# Altium schematic sheet boost_sheet.SchDoc
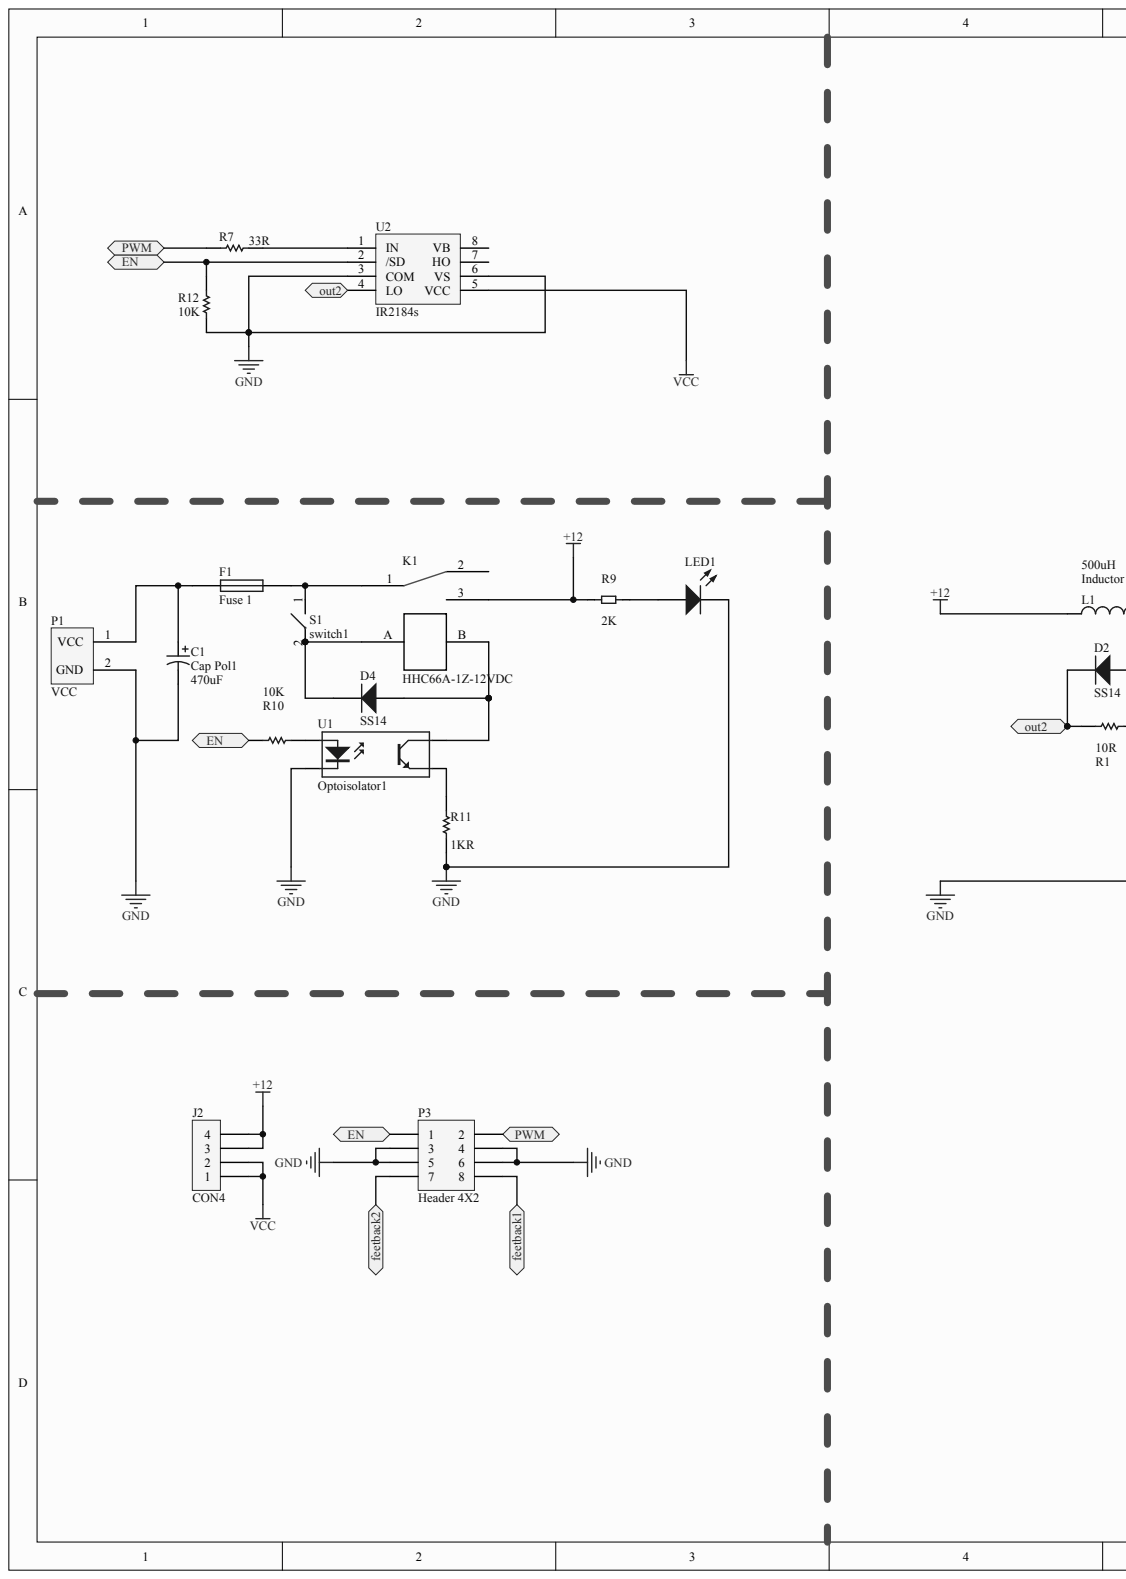
[diagram p0: boost_sheet.SchDoc, left]
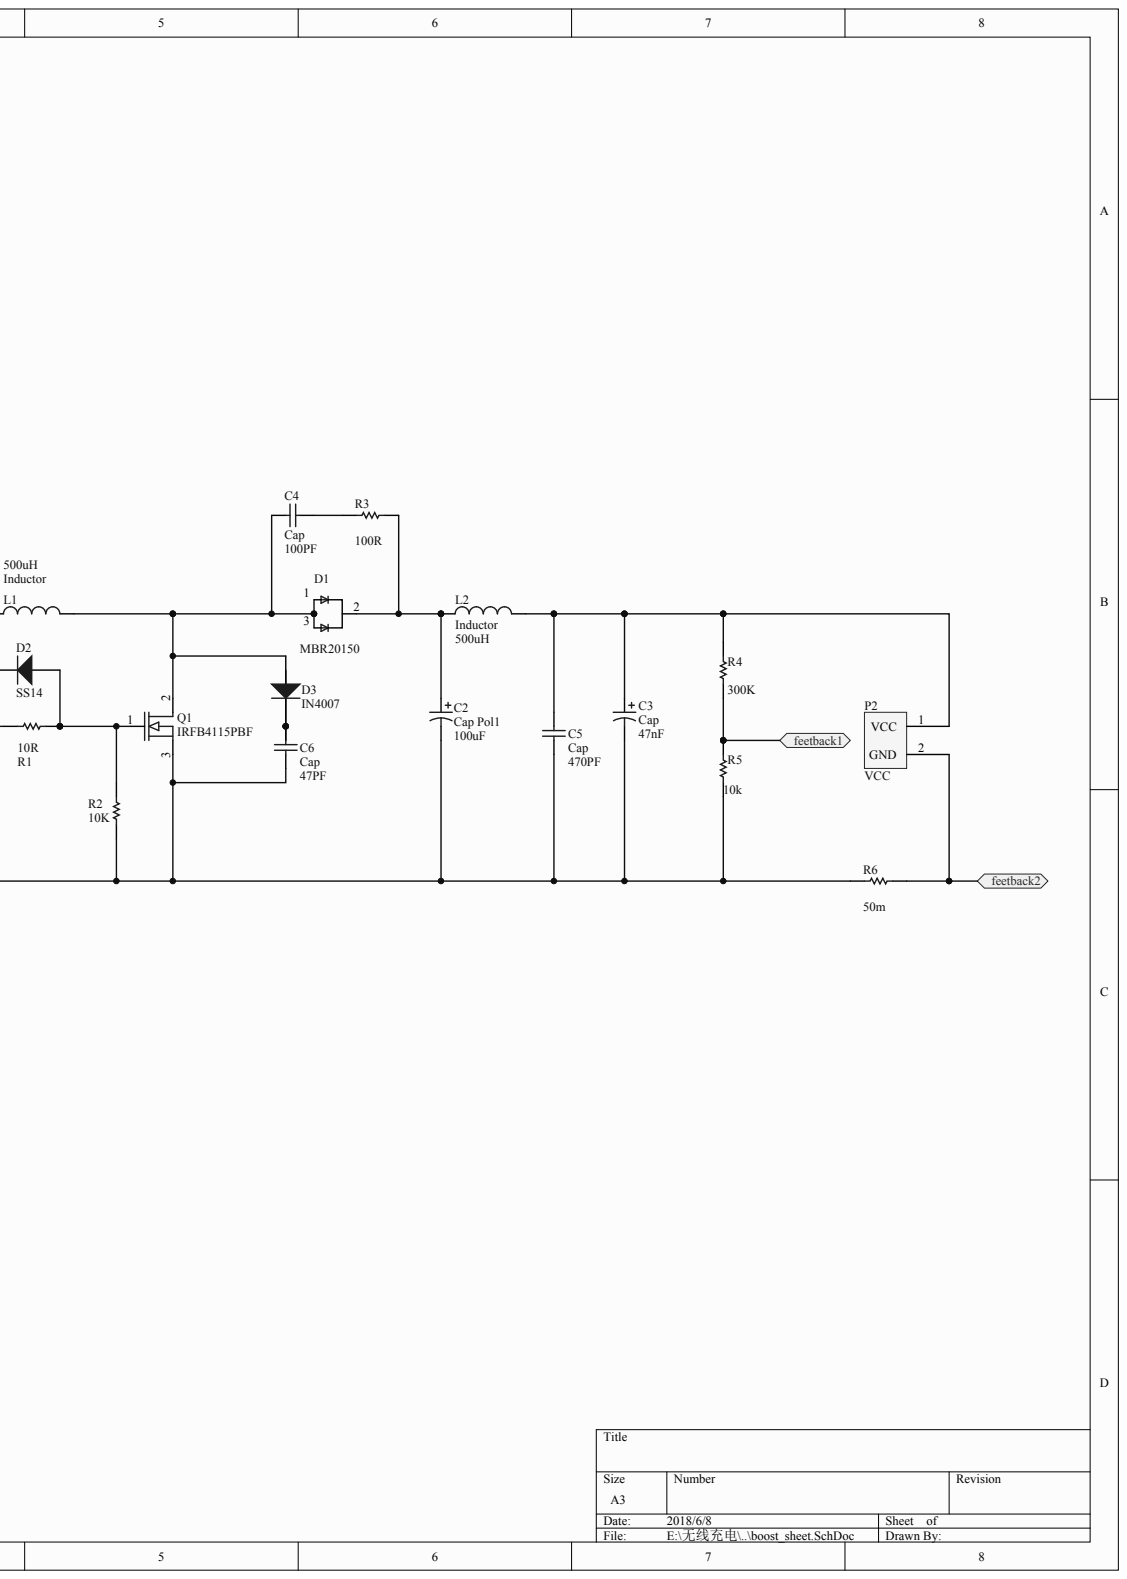
[diagram p1: boost_sheet.SchDoc, right]

## Parts
C1  Cap Pol1  value 470uF
C2  Cap Pol1  value 100uF
C3  Cap  value 47nF
C4  Cap  value 100PF
C5  Cap  value 470PF
C6  Cap  value 47PF
D1  MBR20150
D2  SS14
D3  IN4007
D4  SS14
F1  Fuse 1
J2  CON4
K1  HHC66A-1Z-12VDC
L1  Inductor  value 500uH
L2  Inductor  value 500uH
LED1  (no value)
P1  VCC
P2  VCC
P3  Header 4X2
Q1  IRFB4115PBF
R1  value 10R  (hidden comment: Res1)
R2  value 10K  (hidden comment: Res1)
R3  value 100R  (hidden comment: Res1)
R4  value 300K  (hidden comment: Res1)
R5  value 10k  (hidden comment: Res1)
R6  value 50m  (hidden comment: Res1)
R7  value 33R  (hidden comment: Res1)
R9  2K
R10  value 10K  (hidden comment: Res1)
R11  value 1KR  (hidden comment: Res1)
R12  value 10K  (hidden comment: Res1)
S1  switch1
U1  Optoisolator1
U2  IR2184s

## Ports
EN, FEETBACK1, FEETBACK2, OUT2, PWM

## Nets
+12: J2.3 J2.4 K1.3 L1.1 R9.2
GND: C1.2 C2.2 C3.2 C5.1 C6.1 LED1.2 P1.2 P3.3 P3.4 P3.5 P3.6 Q1.3 R2.1 R5.1 R6.2 R11.1 R12.1 U1.2 U2.3(COM) U2.6(VS)
VCC: J2.1 J2.2 U2.5(VCC)
EN: P3.1 R10.1 R12.2 U2.2(/SD) ; port EN
PWM: P3.2 R7.1 ; port PWM
NetC1_1: C1.1 F1.1 P1.1
NetC2_1: C2.1 D1.2 L2.1 R3.1
NetC3_1: C3.1 C5.2 L2.2 P2.1 R4.2
NetC4_1: C4.1 R3.2
NetC4_2: C4.2 D1.1 D1.3 D3.1 L1.2 Q1.2
NetC6_2: C6.2 D3.2
NetD2_1: D2.1 Q1.1 R1.2 R2.2
NetD2_2: D2.2 R1.1 U2.4(LO) ; port OUT2
NetD4_1: D4.1 K1.B U1.4
NetD4_2: D4.2 K1.A S1.2
NetF1_2: F1.2 K1.1 S1.1
NetLED1_1: LED1.1 R9.1
NetP2_2: P2.2 P3.7 R6.1 ; port FEETBACK2
NetP3_8: P3.8 R4.1 R5.2 ; port FEETBACK1
NetR7_2: R7.2 U2.1(IN)
NetR10_2: R10.2 U1.1
NetR11_2: R11.2 U1.3

Not connected: K1 2; U2 7(HO) 8(VB)

## Library data of the parts
L1 L2 = (no symbol name): Code_IEC: 1005; Published: 8-Jun-2000; LatestRevisionDate: 29-May-2009; LatestRevisionNote: IPC-7351 Footprint Added.; PackageReference: 0402-A; PackageDescription: Chip Inductor; Code_JEITA: 0402; Publisher: Altium Limited
C1-C6 D1-D4 F1 J2 K1 LED1 P1-P3 Q1 R1-R7 R9-R12 S1 U1 U2 = (no symbol name)
  C1-C3: Published: 8-Jun-2000; LatestRevisionDate: 17-Jul-2002; LatestRevisionNote: Re-released for DXP Platform.; PackageReference: RB7.6-15; PackageDescription: Polarized Capacitor (Radial); 2 Leads
  C4-C6: Published: 8-Jun-2000; LatestRevisionDate: 17-Jul-2002; LatestRevisionNote: Re-released for DXP Platform.; PackageReference: RAD-0.3; PackageDescription: Radial Cap, Thru-Hole; 2 Leads; 0.3 in Pin Spacing
  D2-D4: Published: 8-Jun-2000; LatestRevisionDate: 17-Jul-2002; LatestRevisionNote: Re-released for DXP Platform.; PackageVersion: Sep-1996; PackageReference: SMC; PackageDescription: DO-214-AB/SMC; 2 C-Bend Leads; Body 7.9 x 5.9 mm, inc. leads (LxW); Code_JEDEC: DO-214-AB
  F1: Published: 8-Jun-2000; LatestRevisionDate: 17-Jul-2002; LatestRevisionNote: Re-released for DXP Platform.; PackageReference: PIN-W2/E2.8; PackageDescription: Thru-Hole; 2 Leads
  LED1: Published: 8-Jun-2000; LatestRevisionDate: 17-Jul-2002; LatestRevisionNote: Re-released for DXP Platform.; PackageVersion: Feb-2002; PackageReference: 3.2X1.6X1.1; PackageDescription: SM LED; 2 Flat Leads
  P3: LatestRevisionDate: 17-Jul-2002; LatestRevisionNote: Re-released for DXP Platform.
  R1-R7 R10-R12: Published: 8-Jun-2000; LatestRevisionDate: 17-Jul-2002; LatestRevisionNote: Re-released for DXP Platform.; PackageReference: AXIAL-0.3; PackageDescription: Axial Device, Thru-Hole; 2 Leads; 0.3 in Pin Spacing
  U1: Published: 24-Mar-1999; LatestRevisionDate: 04-Mar-2005; LatestRevisionNote: Sch pins 3 & 4 Swapped.; DatasheetVersion: Nov-2003; PackageReference: DIP-4
  C1-C6 D2-D4 F1 LED1 P3 R1-R7 R10-R12 U1: Publisher: Altium Limited
